# Altium schematic sheet CONNECTORS.SchDoc
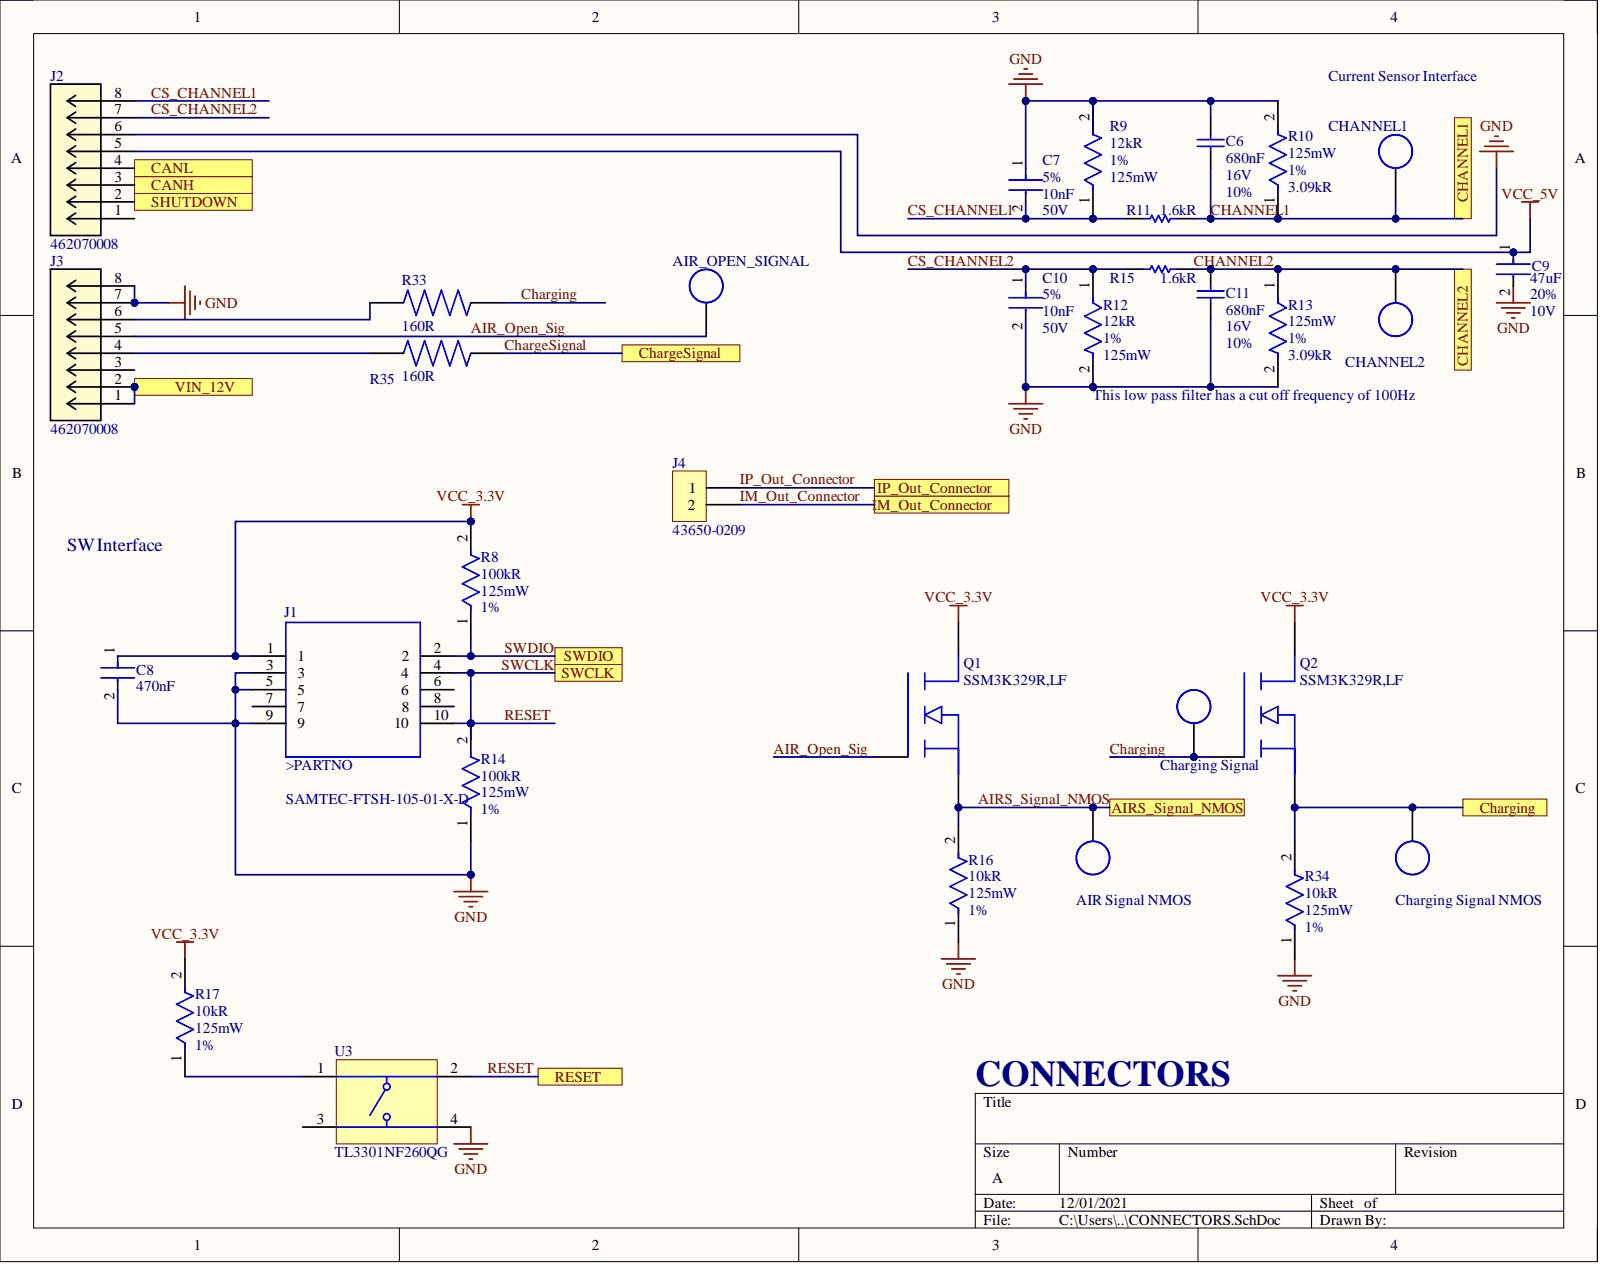
[diagram p0: CONNECTORS.SchDoc]

## Parts
AIR_Signal_NMOS  5015  [5015]  (value not drawn)
AIR_OPEN_SIGNAL  5015  [5015]  (value not drawn)
C6  Capacitance: 680nF  Tolerance: 10%  Voltage: 16V  [CAPC2013X95X45NL10T25]  (hidden comment: GRM219R71C684KA01D)
C7  Capacitance: 10nF  Tolerance: 5%  Voltage: 50V  [FP-GRM219-0_1-IPC_B]  (hidden comment: GRM2195C1H103JA01D)
C8  470nF  [FP-GCM21B-0_15-IPC_A]
C9  Capacitance: 47uF  Tolerance: 20%  Voltage: 10V  [FP-GRM21B-0_2-e0_2_0_7-IPC_A]  (hidden comment: GRM21BR61A476ME15L)
C10  Capacitance: 10nF  Tolerance: 5%  Voltage: 50V  [FP-GRM219-0_1-IPC_B]  (hidden comment: GRM2195C1H103JA01D)
C11  Capacitance: 680nF  Tolerance: 10%  Voltage: 16V  [CAPC2013X95X45NL10T25]  (hidden comment: GRM219R71C684KA01D)
CHANNEL1  5015  [5015]  (value not drawn)
CHANNEL2  5015  [5015]  (value not drawn)
Charging_Signal  5015  [5015]  (value not drawn)
Charging_Signal_NMOS  5015  [5015]  (value not drawn)
J1  SAMTEC-FTSH-105-01-X-D  [SAMTEC-FTSH-105-01-X-D]
J2  462070008  [CON_462070008]
J3  462070008  [CON_462070008]
J4  43650-0209  [43650-0209]
Q1  SSM3K329R,LF  [SOT23-3]
Q2  SSM3K329R,LF  [SOT23-3]
R8  Max Power Dissipation: 125mW  Resistance: 100kR  Resistance Tolerance: 1%  [FP-CRCW0805-e3-MFG]  (hidden comment: CRCW0805100KFKEA)
R9  Max Power Dissipation: 125mW  Resistance: 12kR  Resistance Tolerance: 1%  [FP-CRCW0805-e3-IPC_A]  (hidden comment: CRCW080512K0FKEA)
R10  Max Power Dissipation: 125mW  Resistance: 3.09kR  Resistance Tolerance: 1%  [FP-CRCW0805-e3-IPC_C]  (hidden comment: CRCW08053K09FKEA)
R11  Resistance: 1.6kR  [RESC2013X50X30LL20T20]  (hidden comment: CRCW08051K60FKEA)
R12  Max Power Dissipation: 125mW  Resistance: 12kR  Resistance Tolerance: 1%  [FP-CRCW0805-e3-IPC_A]  (hidden comment: CRCW080512K0FKEA)
R13  Max Power Dissipation: 125mW  Resistance: 3.09kR  Resistance Tolerance: 1%  [FP-CRCW0805-e3-IPC_C]  (hidden comment: CRCW08053K09FKEA)
R14  Max Power Dissipation: 125mW  Resistance: 100kR  Resistance Tolerance: 1%  [FP-CRCW0805-e3-MFG]  (hidden comment: CRCW0805100KFKEA)
R15  Resistance: 1.6kR  [RESC2013X50X30LL20T20]  (hidden comment: CRCW08051K60FKEA)
R16  Max Power Dissipation: 125mW  Resistance: 10kR  Resistance Tolerance: 1%  [FP-CRCW0805-e3-IPC_A]  (hidden comment: CRCW080510K0FKEA)
R17  Max Power Dissipation: 125mW  Resistance: 10kR  Resistance Tolerance: 1%  [FP-CRCW0805-e3-IPC_A]  (hidden comment: CRCW080510K0FKEA)
R33  Resistance: 160R  [RES_ERJP06J161V]  (hidden comment: ERJP06J161V)
R34  Max Power Dissipation: 125mW  Resistance: 10kR  Resistance Tolerance: 1%  [FP-CRCW0805-e3-IPC_A]  (hidden comment: CRCW080510K0FKEA)
R35  Resistance: 160R  [RES_ERJP06J161V]  (hidden comment: ERJP06J161V)
U3  TL3301NF260QG  [TECO-FSM4JSMA_V]

## Ports
AIRS_Signal_NMOS, CANH, CANL, CHANNEL1, CHANNEL2, ChargeSignal, Charging, IM_Out_Connector, IP_Out_Connector, RESET, SHUTDOWN, SWCLK, SWDIO, VIN_12V

## Nets
GND: C6.2 C7.1 C8.2 C9.2 C10.2 C11.1 J1.3 J1.5 J1.9 J2.6 J3.7 J3.8 R9.2 R10.2 R12.2 R13.2 R14.1 R16.1 R34.1 U3.4
VCC_3.3V: C8.1 J1.1 Q1.3 Q2.3 R8.2 R17.2
VCC_5V: C9.1 J2.5
AIR_Open_Sig: AIR_OPEN_SIGNAL.1 J3.5 Q1.1
AIRS_Signal_NMOS: AIR_Signal_NMOS.1 Q1.2 R16.2 ; port AIRS_Signal_NMOS
CANH: J2.3 ; port CANH
CANL: J2.4 ; port CANL
CHANNEL1: C6.1 CHANNEL1.1 R10.1 R11.2 ; port CHANNEL1
CHANNEL2: C11.2 CHANNEL2.1 R13.1 R15.2 ; port CHANNEL2
ChargeSignal: R35.1 ; port ChargeSignal
Charging: Charging_Signal.1 Q2.1 R33.1
CS_CHANNEL1: C7.2 J2.8 R9.1 R11.1
CS_CHANNEL2: C10.1 J2.7 R12.1 R15.1
IM_Out_Connector: J4.2 ; port IM_Out_Connector
IP_Out_Connector: J4.1 ; port IP_Out_Connector
RESET: J1.4 J1.10 R14.2 U3.2 ; port RESET, port SWCLK
SHUTDOWN: J2.2 ; port SHUTDOWN
SWDIO: J1.2 R8.1 ; port SWDIO
VIN_12V: J3.1 J3.2 ; port VIN_12V
NetCharging Signal NMOS_1: Charging_Signal_NMOS.1 Q2.2 R34.2 ; port Charging
NetJ3_4: J3.4 R35.2
NetJ3_6: J3.6 R33.2
NetR17_1: R17.1 U3.1

Not connected: J1 6 7 8; J2 1; J3 3; U3 3

## Library data of the parts
AIR_OPEN_SIGNAL AIR_Signal_NMOS CHANNEL1 CHANNEL2 Charging_Signal Charging_Signal_NMOS = 5015: SMD Test Point; Manufacturer: Keystone Electronics; TargetLibrary: RITRacing-Connectors; Component Kind: Standard; ComponentLink1Description: Datasheet; ComponentLink1URL: http://www.mouser.com/ds/2/215/005-5009-743680.pdf; Library Name: Connectors.IntLib; PartNumber: 5015; Published: 22Oct2016; Status: Active; Publisher: T.Tallo
C6 C11 = CMP-2007-00190-1: Manufacturer: Murata; Dielectric: X7R; Material: Ceramic; Case Code (Imperial): 0805; Case Code (Metric): 2012; Case/Package: 0805; Category: Ceramic Capacitors; Component Kind: Standard; ComponentLink1Description: https://datasheet.ciiva.com/136/grm219r71c684ka01-01-136854.pdf?src-supplier=Digi-Key; ComponentLink1URL: https://datasheet.ciiva.com/136/grm219r71c684ka01-01-136854.pdf?src-supplier=Digi-Key; ComponentLink2Description: https://datasheet.ciiva.com/136/grm219r71c684ka01-01-136855.pdf?src-supplier=Digi-Key; ComponentLink2URL: https://datasheet.ciiva.com/136/grm219r71c684ka01-01-136855.pdf?src-supplier=Digi-Key; ComponentLink3Description: https://datasheet.ciiva.com/136/grm219r71c684ka01-01-136856.pdf?src-supplier=Digi-Key; ComponentLink3URL: https://datasheet.ciiva.com/136/grm219r71c684ka01-01-136856.pdf?src-supplier=Digi-Key; ComponentLink4Description: https://datasheet.ciiva.com/5426/product-5426512.pdf?src-supplier=Mouser; ComponentLink4URL: https://datasheet.ciiva.com/5426/product-5426512.pdf?src-supplier=Mouser; ComponentLink5Description: https://datasheet.ciiva.com/11464/product-11464042.pdf?src-supplier=Avnet; ComponentLink5URL: https://datasheet.ciiva.com/11464/product-11464042.pdf?src-supplier=Avnet; Construction: Flat; Depth: 1.25mm; Height: 0.85mm; Lead Free: Lead Free; Length: 2mm; Library Name: Altium Content Vault; Manufacturer 1: Murata; Manufacturer 2: Murata; Manufacturer 3: Murata; Manufacturer Part Number 1: GRM219R71C684KA01D; Manufacturer Part Number 2: GRM219R71C684KA01D; Manufacturer Part Number 3: GRM219R71C684KA01D; Max Operating Temperature: 125°C; Min Operating Temperature: -55°C; Mount: Surface Mount; Package Quantity: 1; Packaging: Tape and Reel; Part Number: GRM219R71C684KA01D; Pricing 1: 1=0.33000, 10=0.22300, 50=0.14860, 100=0.12630, 500=0.08914, 1000=0.07800 (USD); Pricing 2: 1=0.33000, 10=0.22300, 50=0.14860, 100=0.12630, 500=0.08914, 1000=0.07800 (USD); Pricing 3: 1=0.32000, 10=0.22300, 50=0.22300, 100=0.12700, 500=0.09000, 1000=0.07800, 2000=0.07500, 4000=0.06800, 8000=0.05600, 10000=0.05600 (USD); Radiation Hardening: No; RoHS: Yes; RoHS Compliant: Yes; Series: GRM; Stock 1: 28535; Stock 2: 28535; Stock 3: 83; Supplier 1: Digi-Key; Supplier 2: Digi-Key; Supplier 3: Mouser; Supplier Part Number 1: 490-4741-1-ND; Supplier Part Number 2: 490-4741-6-ND; Supplier Part Number 3: 81-GRM219R71C684KA1D; Termination: SMD/SMT; Thickness: 0.037inch; Voltage Rating: 16V; Voltage Rating (DC): 16V; Width: 1.25mm
C7 C10 = CMP-2007-00135-2: Chip Multilayer Ceramic Capacitors for General Purpose, 0805, 10000pF, C0G, 30ppm/°C, 5%, 50V; Manufacturer 1: Murata; Insulation Resistance: 10GR; Case Code (Imperial): 0805; Case Code (Metric): 2012; Case/Package: 0805; Category: Ceramic Capacitors; Component Kind: Standard; ComponentLink1Description: https://datasheet.ciiva.com/3777/376grm2195c1h103ja0123-3777227.pdf?src-supplier=Arrow+Electronics; ComponentLink1URL: https://datasheet.ciiva.com/3777/376grm2195c1h103ja0123-3777227.pdf?src-supplier=Arrow+Electronics; ComponentLink2Description: https://datasheet.ciiva.com/11786/0900766b807a1cab-11786595.pdf?src-supplier=RSComponents; ComponentLink2URL: https://datasheet.ciiva.com/11786/0900766b807a1cab-11786595.pdf?src-supplier=RSComponents; ComponentLink3Description: https://datasheet.ciiva.com/19476/1858501-19476200.pdf?src-supplier=Jameco; ComponentLink3URL: https://datasheet.ciiva.com/19476/1858501-19476200.pdf?src-supplier=Jameco; ComponentLink4Description: https://datasheet.ciiva.com/29200/452695-da-01-en-vs-kondensator-0805-29200853.pdf?src-supplier=Conrad; ComponentLink4URL: https://datasheet.ciiva.com/29200/452695-da-01-en-vs-kondensator-0805-29200853.pdf?src-supplier=Conrad; ComponentLink5Description: https://datasheet.ciiva.com/138/partnumbering-e-01-138167.pdf?src-supplier=Digi-Key; ComponentLink5URL: https://datasheet.ciiva.com/138/partnumbering-e-01-138167.pdf?src-supplier=Digi-Key; ComponentLink6Description: https://datasheet.ciiva.com/138/partnumbering-e-01-138165.pdf?src-supplier=Digi-Key; ComponentLink6URL: https://datasheet.ciiva.com/138/partnumbering-e-01-138165.pdf?src-supplier=Digi-Key; ComponentLink7Description: https://datasheet.ciiva.com/138/partnumbering-e-01-138166.pdf?src-supplier=Digi-Key; ComponentLink7URL: https://datasheet.ciiva.com/138/partnumbering-e-01-138166.pdf?src-supplier=Digi-Key; ComponentLink8Description: https://datasheet.ciiva.com/2989/product-2989652.pdf?src-supplier=Avnet; ComponentLink8URL: https://datasheet.ciiva.com/2989/product-2989652.pdf?src-supplier=Avnet; ComponentLink9Description: https://datasheet.ciiva.com/11620/product-11620062.pdf?src-supplier=Avnet; ComponentLink9URL: https://datasheet.ciiva.com/11620/product-11620062.pdf?src-supplier=Avnet; ComponentLink10Description: https://datasheet.ciiva.com/7828/4360-7828972.pdf?src-supplier=Element14; ComponentLink10URL: https://datasheet.ciiva.com/7828/4360-7828972.pdf?src-supplier=Element14; ComponentLink11Description: https://datasheet.ciiva.com/7828/1747462-7828970.pdf?src-supplier=Element14; ComponentLink11URL: https://datasheet.ciiva.com/7828/1747462-7828970.pdf?src-supplier=Element14; ComponentLink12Description: https://datasheet.ciiva.com/7828/1747462-7828971.pdf?src-supplier=Element14; ComponentLink12URL: https://datasheet.ciiva.com/7828/1747462-7828971.pdf?src-supplier=Element14; Construction: Flat; Depth: 1.25mm; Dielectric: C0G; Dielectric Material: Ceramic; Height: 0.85mm; Lead Free: Lead Free; Length: 2mm; Library Name: Altium Content Vault; Manufacturer 2: Murata; Manufacturer Part Number 1: GRM2195C1H103JA01D; Manufacturer Part Number 2: GRM2195C1H103JA01D; Material: Ceramic; Max Operating Temperature: 125°C; Min Operating Temperature: -55°C; Mount: Surface Mount; Number of Pins: 2; Package Quantity: 1; Packaging: Tape and Reel; Pricing 1: 1=0.09100, 4000=0.09100 (USD); Pricing 2: 1=0.38000, 10=0.25900, 50=0.17300, 100=0.14700, 500=0.10378, 1000=0.09080 (USD); Radiation Hardening: No; REACH SVHC: No SVHC; RoHS: Yes; RoHS Compliant: Yes; Series: GRM; Size: 0.85mm; Stock 1: 44000; Supplier 1: Newark; Supplier 2: Digi-Key; Supplier Part Number 1: 08AC2712; Supplier Part Number 2: 490-1642-1-ND; Temperature Coefficient: 30ppm/°C; Termination: SMD/SMT; Thickness: 0.037inch; Voltage Rating: 50V; Voltage Rating (DC): 50V; Width: 0.049inch
C8 = CMP-2007-03360-2: Ceramic Capacitor for Automotive 470nF ±10% 50VDC X7R 0805 Embossed T/R; Manufacturer 1: Murata; Manufacturer Part Number 1: GCM21BR71H474KA55K; Voltage Rating: 50V; Capacitance: 470nF; Case Code (Imperial): 0805; Case Code (Metric): 2012; Case/Package: 0805; Category: Ceramic Capacitors; Component Kind: Standard; ComponentLink1Description: https://datasheet.ciiva.com/171/product-171550.pdf?src-supplier=Digi-Key; ComponentLink1URL: https://datasheet.ciiva.com/171/product-171550.pdf?src-supplier=Digi-Key; ComponentLink2Description: https://datasheet.ciiva.com/11673/product-11673422.pdf?src-supplier=Avnet; ComponentLink2URL: https://datasheet.ciiva.com/11673/product-11673422.pdf?src-supplier=Avnet; Construction: Flat; Depth: 1.25mm; Dielectric: X7R; Height: 1.25mm; Length: 2mm; Library Name: Altium Content Vault; Max Operating Temperature: 125°C; Min Operating Temperature: -55°C; Mount: Surface Mount; Package Quantity: 10000; Packaging: Tape and Reel; Pricing 1: 1=0.30000, 10=0.20800, 50=0.13860, 100=0.11780, 500=0.08318, 1000=0.07279, 2500=0.06932, 5000=0.06412 (USD); Radiation Hardening: No; Ratings: AEC-Q200; RoHS: Yes; RoHS Compliant: Yes; Series: GCM; Stock 1: 26700; Supplier 1: Digi-Key; Supplier Part Number 1: 490-11164-1-ND; Termination: SMD/SMT; Thickness: 0.055inch; Tolerance: 10%; Voltage Rating (DC): 50V; Width: 0.049inch
C9 = CMP-2000-06341-2: Chip Multilayer Ceramic Capacitors for General Purpose, 0805, 47uF, X5R, 15%, 20%, 10V; Manufacturer 1: Murata; Dissipation Factor: 0.035%; Case Code (Imperial): 0805; Case Code (Metric): 2012; Case/Package: 0805; Category: Ceramic Capacitors; Component Kind: Standard; ComponentLink1Description: http://www.farnell.com/datasheets/2079183.pdf?src-supplier=Farnell; ComponentLink1URL: http://www.farnell.com/datasheets/2079183.pdf?src-supplier=Farnell; ComponentLink2Description: http://components.arrow.com/part/dataview/0323_07199600?src-supplier=Arrow+Europe; ComponentLink2URL: http://components.arrow.com/part/dataview/0323_07199600?src-supplier=Arrow+Europe; ComponentLink3Description: http://psearch.en.murata.com/capacitor/product/GRM21BR61A476ME15%23.pdf?src-supplier=Avnet; ComponentLink3URL: http://psearch.en.murata.com/capacitor/product/GRM21BR61A476ME15%23.pdf?src-supplier=Avnet; ComponentLink4Description: https://search.murata.co.jp/Ceramy/image/img/A01X/G101/ENG/GRM21BR61A476ME15-01.pdf?src-supplier=Digi-Key; ComponentLink4URL: https://search.murata.co.jp/Ceramy/image/img/A01X/G101/ENG/GRM21BR61A476ME15-01.pdf?src-supplier=Digi-Key; ComponentLink5Description: http://search.murata.co.jp/Ceramy/image/img/A01X/G101/ENG/GRM21BR61A476ME15-01.pdf?src-supplier=Digi-Key; ComponentLink5URL: http://search.murata.co.jp/Ceramy/image/img/A01X/G101/ENG/GRM21BR61A476ME15-01.pdf?src-supplier=Digi-Key; ComponentLink6Description: http://www.farnell.com/datasheets/2079183.pdf?src-supplier=Element14; ComponentLink6URL: http://www.farnell.com/datasheets/2079183.pdf?src-supplier=Element14; ComponentLink7Description: http://www.farnell.com/datasheets/2079183.pdf?src-supplier=Newark; ComponentLink7URL: http://www.farnell.com/datasheets/2079183.pdf?src-supplier=Newark; Depth: 1.25mm; Dielectric: X5R; Dielectric Material: Ceramic; Height: 1.25mm; Lead Free: Lead Free; Length: 2mm; Library Name: Altium Content Vault; Manufacturer Part Number 1: GRM21BR61A476ME15L; Max Operating Temperature: 85°C; Min Operating Temperature: -55°C; Mount: Surface Mount; Packaging: Tape and Reel; Pricing 1: 3000=0.19360 (USD); REACH SVHC: No SVHC; RoHS: Yes; RoHS Compliant: Yes; Stock 1: 291985; Supplier 1: Digi-Key; Supplier Part Number 1: 490-9961-2-ND; Termination: SMD/SMT; Thickness: 0.057inch; Voltage Rating: 10V; Voltage Rating (DC): 10V; Width: 0.049inch
J1 = SAMTEC-FTSH-105-01-X-D: CONN HEADER SMD 10POS 1.27MM; Manufacturer 1: Samtec; Manufacturer Part Number 1: FTSH-105-01-L-DV-K; Connector Type: Male Pin; Gender: Male; Max Current Rating: 1.75A; MP: FTSH-105-01-F-D; Number of Contacts: 10; Row Spacing: 0.05inch; Availability: Unavailable; Category: Headers and Wire Housings; Color: Black; Component Kind: Standard; ComponentLink1Description: https://datasheet.ciiva.com/26936/getdatasheetpartid-175877-26936879.pdf?src-supplier=Verical; ComponentLink1URL: https://datasheet.ciiva.com/26936/getdatasheetpartid-175877-26936879.pdf?src-supplier=Verical; ComponentLink2Description: https://datasheet.ciiva.com/22703/ftsh-smt-22703900.pdf?src-supplier=Element14; ComponentLink2URL: https://datasheet.ciiva.com/22703/ftsh-smt-22703900.pdf?src-supplier=Element14; ComponentLink3Description: https://datasheet.ciiva.com/10245/ftsh-mt-10245272.pdf?src-supplier=Avnet; ComponentLink3URL: https://datasheet.ciiva.com/10245/ftsh-mt-10245272.pdf?src-supplier=Avnet; ComponentLink4Description: https://datasheet.ciiva.com/16657/ftsh-mt-16657372.pdf?src-supplier=Avnet+Europe; ComponentLink4URL: https://datasheet.ciiva.com/16657/ftsh-mt-16657372.pdf?src-supplier=Avnet+Europe; ComponentLink5Description: https://datasheet.ciiva.com/728/ftsh-1xx-xx-xxx-dv-xxx-mkt-728872.pdf?src-supplier=Digi-Key; ComponentLink5URL: https://datasheet.ciiva.com/728/ftsh-1xx-xx-xxx-dv-xxx-mkt-728872.pdf?src-supplier=Digi-Key; Contact Material: Bronze; Contact Mating Length: 0.12inch; Contact Plating: Gold; Contact Type: Male Pin; Depth: 3.43mm; Housing Color: Black; Housing Material: Polymer; Lead Free: Lead Free; Length: 6.35mm; Library Name: SnapEDAsch.SchLib; Max Operating Temperature: 125°C; MF: Samtec; Min Operating Temperature: -55°C; Mount: Surface Mount; Number of Positions: 10; Number of Rows: 2; Orientation: Straight; Package: None; Package Quantity: 1; Packaging: Rail/Tube; Pitch: 1.27mm; Price: None; Pricing 1: 1=3.91000, 10=3.75700, 92=3.13098, 460=2.66135, 1012=2.26997 (USD); Radiation Hardening: No; REACH SVHC: No SVHC; RoHS: Yes; RoHS Compliant: Yes; Series: FTSH; SnapEDA_Link: https://www.snapeda.com/parts/FTSH-105-01-F-D/Samtec%20Inc./view-part/105348/?ref=snap; Stock 1: 38057; Supplier 1: Digi-Key; Supplier Part Number 1: SAM8799-ND; Termination: Solder
J2 J3 = 462070008: Conn Power HDR 8 POS 4.2mm Solder ST Thru-Hole 8 Terminal 1 Port Mini-Fit Jr™ Frame; Manufacturer 1: Molex; Manufacturer Part Number 1: 46207-0008; Mfr_Name: Molex Connector Corporation; Connector Type: Male Pin; Current Rating: 13A; Glow Wire Compliant: Compliant; Max Voltage Rating (DC): 600.6kV; Number of Circuits: 8; Row Spacing: 0.165inch; Voltage Rating: 600V; Category: Headers and Wire Housings; Color: Black; Component Kind: Standard; ComponentLink1Description: https://datasheet.ciiva.com/726/462070004-sd-726556.pdf?src-supplier=Digi-Key; ComponentLink1URL: https://datasheet.ciiva.com/726/462070004-sd-726556.pdf?src-supplier=Digi-Key; ComponentLink2Description: https://datasheet.ciiva.com/5159/0462070008-pcb-headers-169853-5159064.pdf?src-supplier=Mouser; ComponentLink2URL: https://datasheet.ciiva.com/5159/0462070008-pcb-headers-169853-5159064.pdf?src-supplier=Mouser; ComponentLink3Description: https://datasheet.ciiva.com/11751/0900766b80b0ac72-11751180.pdf?src-supplier=RSComponents; ComponentLink3URL: https://datasheet.ciiva.com/11751/0900766b80b0ac72-11751180.pdf?src-supplier=RSComponents; ComponentLink4Description: https://datasheet.ciiva.com/30199/145480462070008-pcb-headers-30199794.pdf?src-supplier=Onlinecomponents.com; ComponentLink4URL: https://datasheet.ciiva.com/30199/145480462070008-pcb-headers-30199794.pdf?src-supplier=Onlinecomponents.com; ComponentLink5Description: https://datasheet.ciiva.com/25289/1630792-25289386.pdf?src-supplier=Newark; ComponentLink5URL: https://datasheet.ciiva.com/25289/1630792-25289386.pdf?src-supplier=Newark; ComponentLink6Description: https://datasheet.ciiva.com/16653/0462070008-pcb-headers-16653783.pdf?src-supplier=Avnet+Europe; ComponentLink6URL: https://datasheet.ciiva.com/16653/0462070008-pcb-headers-16653783.pdf?src-supplier=Avnet+Europe; Contact Gender: Male; Contact Material: Brass; Contact Plating: Tin; Contact Type: Male Pin; Fastening Type: Ramp; Halogen Free: Low Halogen; Housing Material: Polymer; Library Name: SnapEDAsch.SchLib; Manufacturer_Part_Number: 462070008; Max Operating Temperature: 105°C; Min Operating Temperature: -40°C; Mount: Through Hole; Number of Contacts: 8; Number of Positions: 8; Number of Rows: 2; Orientation: Vertical; Package Quantity: 1; Packaging: Tray; Pitch: 4.2mm; Pricing 1: 1=2.76000, 10=2.34700, 80=1.93888, 3120=1.42868, 6240=1.35724 (USD); Radiation Hardening: No; RefDes: RefDes; RoHS: Yes; RoHS Compliant: Yes; Series: Mini-Fit® 46207; Stock 1: 3111; Supplier 1: Digi-Key; Supplier Part Number 1: WM21377-ND; Termination: Solder; Type: DEV; Voltage Rating (AC): 600V; Copyright: Copyright (C) 2021 Ultra Librarian. All rights reserved.
J4 = 43650-0209: Molex 2 Position Board Header, RIght Angle; TargetLibrary: RITRacing-Connectors; Voltage: 600V; Component Kind: Standard; ComponentLink1Description: Datasheet; ComponentLink1URL: http://www.mouser.com/ds/2/418/NG_CD_1445088_A1-614413.pdf; Library Name: Connectors.IntLib; Manufacturer: Molex; PartNumber: 43650-0209; Published: 13Aug2018; Status: Active; Supplier 1: Mouser; Supplier 2: Digi-Key; Supplier Part Number 1: 538-43650-0209; Publisher: T.Tallo
Q1 Q2 = SSM3K329R,LF: N-Channel MOSFET, 30V, 3.5A; Manufacturer: Toshiba; TargetLibrary: RITRacing-Transistors; Component Kind: Standard; ComponentLink1Description: Datasheet; ComponentLink1URL: See Digikey; Library Name: Transistors.IntLib; PartNumber: SSM3K329R,LF; Published: 09/27/2017; Status: Active; Supplier 1: Digi-Key; Supplier Part Number 1: SSM3K329RLFDKR-ND; Publisher: T.Tallo
R8 R14 = CMP-2001-04899-2: RES Thick Film, 100kΩ, 1%, 0.125W, 100ppm/°C, 0805; Manufacturer 1: Vishay; Voltage Rating: 150V; Case Code (Imperial): 0805; Case Code (Metric): 2012; Case/Package: 0805; Category: Chip SMD Resistors; Component Kind: Standard; ComponentLink1Description: https://datasheet.ciiva.com/11809/0900766b80d98b26-11809057.pdf?src-supplier=RSComponents; ComponentLink1URL: https://datasheet.ciiva.com/11809/0900766b80d98b26-11809057.pdf?src-supplier=RSComponents; ComponentLink2Description: https://datasheet.ciiva.com/8337/dcrcwe3-8337738.pdf?src-supplier=Avnet; ComponentLink2URL: https://datasheet.ciiva.com/8337/dcrcwe3-8337738.pdf?src-supplier=Avnet; ComponentLink3Description: https://datasheet.ciiva.com/3258/dcrcwe3-3258006.pdf?src-supplier=Avnet; ComponentLink3URL: https://datasheet.ciiva.com/3258/dcrcwe3-3258006.pdf?src-supplier=Avnet; ComponentLink4Description: https://datasheet.ciiva.com/16754/70201119-16754822.pdf?src-supplier=Allied+Electronics; ComponentLink4URL: https://datasheet.ciiva.com/16754/70201119-16754822.pdf?src-supplier=Allied+Electronics; ComponentLink5Description: https://datasheet.ciiva.com/7777/1697337-7777349.pdf?src-supplier=Element14; ComponentLink5URL: https://datasheet.ciiva.com/7777/1697337-7777349.pdf?src-supplier=Element14; ComponentLink6Description: https://datasheet.ciiva.com/7777/1720486-7777565.pdf?src-supplier=Element14; ComponentLink6URL: https://datasheet.ciiva.com/7777/1720486-7777565.pdf?src-supplier=Element14; ComponentLink7Description: https://datasheet.ciiva.com/16341/dcrcwe3-16341530.pdf?src-supplier=Future+Electronics; ComponentLink7URL: https://datasheet.ciiva.com/16341/dcrcwe3-16341530.pdf?src-supplier=Future+Electronics; ComponentLink8Description: https://datasheet.ciiva.com/16852/dcrcwe3-16852810.pdf?src-supplier=Avnet+Europe; ComponentLink8URL: https://datasheet.ciiva.com/16852/dcrcwe3-16852810.pdf?src-supplier=Avnet+Europe; ComponentLink9Description: https://datasheet.ciiva.com/16720/70201119-16720891.pdf?src-supplier=Allied+Electronics; ComponentLink9URL: https://datasheet.ciiva.com/16720/70201119-16720891.pdf?src-supplier=Allied+Electronics; Composition: Thick Film; Construction: Rectangular; Contact Plating: Tin; Depth: 1.25mm; Features: Automotive AEC-Q200; Height: 0.45mm; Lead Free: Lead Free; Length: 2mm; Library Name: Altium Content Vault; Manufacturer Part Number 1: CRCW0805100KFKEA; Max Operating Temperature: 155°C; Military Standard: Not; Min Operating Temperature: -55°C; Mount: Surface Mount; Number of Pins: 2; Number of Terminations: 2; Package Quantity: 5000; Packaging: Tape and Reel; Power Rating: 125mW; Pricing 1: 1=0.10000, 10=0.06700, 100=0.02710, 1000=0.01219, 2500=0.01058 (USD); Radiation Hardening: No; REACH SVHC: Unknown; Resistor Type: Thick Film; RoHS: Yes; RoHS Compliant: Yes; Series: CRCW; Size Code: 0805; Stock 1: 135309; Supplier 1: Digi-Key; Supplier Part Number 1: 541-100KCCT-ND; Technology: THICK FILM; Temperature Coefficient: 100ppm/°C; Termination: SMD/SMT; Tolerance: 1%; Voltage Rating (DC): 150V; Weight: 0.000194oz; Width: 1.25mm
R9 R12 = CMP-2001-04934-2: RES Thick Film, 12kΩ, 1%, 0.125W, 100ppm/°C, 0805; Manufacturer 1: Vishay; Voltage Rating: 150V; Case Code (Imperial): 0805; Case Code (Metric): 2012; Case/Package: 0805; Category: Chip SMD Resistors; Component Kind: Standard; ComponentLink1Description: https://datasheet.ciiva.com/11809/0900766b80d98b26-11809216.pdf?src-supplier=RSComponents; ComponentLink1URL: https://datasheet.ciiva.com/11809/0900766b80d98b26-11809216.pdf?src-supplier=RSComponents; ComponentLink2Description: https://datasheet.ciiva.com/2467/dcrcwe3-2467344.pdf?src-supplier=Digi-Key; ComponentLink2URL: https://datasheet.ciiva.com/2467/dcrcwe3-2467344.pdf?src-supplier=Digi-Key; ComponentLink3Description: https://datasheet.ciiva.com/2467/dcrcwe3-2467345.pdf?src-supplier=Digi-Key; ComponentLink3URL: https://datasheet.ciiva.com/2467/dcrcwe3-2467345.pdf?src-supplier=Digi-Key; ComponentLink4Description: https://datasheet.ciiva.com/2467/dcrcwe3-2467346.pdf?src-supplier=Digi-Key; ComponentLink4URL: https://datasheet.ciiva.com/2467/dcrcwe3-2467346.pdf?src-supplier=Digi-Key; ComponentLink5Description: https://datasheet.ciiva.com/16883/dcrcwe3-16883879.pdf?src-supplier=Avnet+Europe; ComponentLink5URL: https://datasheet.ciiva.com/16883/dcrcwe3-16883879.pdf?src-supplier=Avnet+Europe; ComponentLink6Description: https://datasheet.ciiva.com/16397/dcrcw-16397325.pdf?src-supplier=Future+Electronics; ComponentLink6URL: https://datasheet.ciiva.com/16397/dcrcw-16397325.pdf?src-supplier=Future+Electronics; ComponentLink7Description: https://datasheet.ciiva.com/7841/1697337-7841630.pdf?src-supplier=Element14; ComponentLink7URL: https://datasheet.ciiva.com/7841/1697337-7841630.pdf?src-supplier=Element14; ComponentLink8Description: https://datasheet.ciiva.com/16777/70201119-16777994.pdf?src-supplier=Allied+Electronics; ComponentLink8URL: https://datasheet.ciiva.com/16777/70201119-16777994.pdf?src-supplier=Allied+Electronics; Composition: Thick Film; Construction: Rectangular; Contact Plating: Tin; Depth: 1.25mm; Features: Automotive AEC-Q200; Height: 0.45mm; Lead Free: Lead Free; Length: 2mm; Library Name: Altium Content Vault; Manufacturer Part Number 1: CRCW080512K0FKEA; Max Operating Temperature: 155°C; Military Standard: Not; Min Operating Temperature: -55°C; Mount: Surface Mount; Number of Terminations: 2; Package Quantity: 10; Packaging: Tape and Reel; Power Rating: 125mW; Pricing 1: 1=0.10000, 10=0.06700, 100=0.02710, 1000=0.01219, 2500=0.01058 (USD); Radiation Hardening: No; REACH SVHC: Unknown; Resistor Type: Thick Film; RoHS: Yes; RoHS Compliant: Yes; Series: CRCW; Size Code: 0805; Stock 1: 2287; Supplier 1: Digi-Key; Supplier Part Number 1: 541-12.0KCCT-ND; Technology: THICK FILM; Temperature Coefficient: 100ppm/°C; Termination: SMD/SMT; Tolerance: 1%; Voltage Rating (DC): 150V; Weight: 0.000194oz; Width: 0.049inch
R10 R13 = CMP-2001-03873-2: RES Thick Film, 3.09kΩ, 1%, 0.125W, 100ppm/°C, 0805; Manufacturer 1: Vishay; Voltage Rating: 150V; Case Code (Imperial): 0805; Case Code (Metric): 2012; Case/Package: 0805; Category: Chip SMD Resistors; Component Kind: Standard; ComponentLink1Description: https://datasheet.ciiva.com/9081/dcrcwe3-9081688.pdf?src-supplier=Avnet; ComponentLink1URL: https://datasheet.ciiva.com/9081/dcrcwe3-9081688.pdf?src-supplier=Avnet; ComponentLink2Description: https://datasheet.ciiva.com/2467/dcrcwe3-2467557.pdf?src-supplier=Digi-Key; ComponentLink2URL: https://datasheet.ciiva.com/2467/dcrcwe3-2467557.pdf?src-supplier=Digi-Key; ComponentLink3Description: https://datasheet.ciiva.com/2467/dcrcwe3-2467558.pdf?src-supplier=Digi-Key; ComponentLink3URL: https://datasheet.ciiva.com/2467/dcrcwe3-2467558.pdf?src-supplier=Digi-Key; ComponentLink4Description: https://datasheet.ciiva.com/2467/dcrcwe3-2467559.pdf?src-supplier=Digi-Key; ComponentLink4URL: https://datasheet.ciiva.com/2467/dcrcwe3-2467559.pdf?src-supplier=Digi-Key; ComponentLink5Description: https://datasheet.ciiva.com/5549/dcrcwe3-109170-5549629.pdf?src-supplier=Mouser; ComponentLink5URL: https://datasheet.ciiva.com/5549/dcrcwe3-109170-5549629.pdf?src-supplier=Mouser; ComponentLink6Description: https://datasheet.ciiva.com/7670/1697337-7670298.pdf?src-supplier=Element14; ComponentLink6URL: https://datasheet.ciiva.com/7670/1697337-7670298.pdf?src-supplier=Element14; ComponentLink7Description: https://datasheet.ciiva.com/7670/1697337-7670299.pdf?src-supplier=Element14; ComponentLink7URL: https://datasheet.ciiva.com/7670/1697337-7670299.pdf?src-supplier=Element14; ComponentLink8Description: https://datasheet.ciiva.com/22675/1847332-22675199.pdf?src-supplier=Element14; ComponentLink8URL: https://datasheet.ciiva.com/22675/1847332-22675199.pdf?src-supplier=Element14; ComponentLink9Description: https://datasheet.ciiva.com/7178/1635184-7178791.pdf?src-supplier=Newark; ComponentLink9URL: https://datasheet.ciiva.com/7178/1635184-7178791.pdf?src-supplier=Newark; ComponentLink10Description: https://datasheet.ciiva.com/22871/70201119-22871769.pdf?src-supplier=Allied+Electronics; ComponentLink10URL: https://datasheet.ciiva.com/22871/70201119-22871769.pdf?src-supplier=Allied+Electronics; ComponentLink11Description: https://datasheet.ciiva.com/16780/70201119-16780488.pdf?src-supplier=Allied+Electronics; ComponentLink11URL: https://datasheet.ciiva.com/16780/70201119-16780488.pdf?src-supplier=Allied+Electronics; Composition: Thick Film; Construction: Rectangular; Contact Plating: Tin; Depth: 1.25mm; Features: Automotive AEC-Q200; Height: 0.02inch; Lead Free: Lead Free; Length: 2mm; Library Name: Altium Content Vault; Manufacturer 2: Vishay; Manufacturer 3: Vishay; Manufacturer Part Number 1: CRCW08053K09FKEA; Manufacturer Part Number 2: CRCW08053K09FKEA; Manufacturer Part Number 3: CRCW08053K09FKEA; Max Operating Temperature: 155°C; Military Standard: Not; Min Operating Temperature: -55°C; Number of Pins: 2; Number of Terminations: 2; Package Quantity: 10; Packaging: Tape and Reel; Power Rating: 125mW; Pricing 1: 1=0.10000, 10=0.06700, 100=0.02710, 1000=0.01219, 2500=0.01058 (USD); Pricing 2: 1=0.10000, 10=0.06700, 100=0.02710, 1000=0.01219, 2500=0.01058 (USD); Pricing 3: 1=0.18000, 10=0.06800, 50=0.06800, 100=0.02800, 1000=0.01500, 10000=0.00900 (USD); Radiation Hardening: No; REACH SVHC: Unknown; Resistor Type: Thick Film; RoHS: Yes; RoHS Compliant: Yes; Series: CRCW; Size Code: 0805; Stock 1: 4900; Stock 2: 4900; Stock 3: 6174; Supplier 1: Digi-Key; Supplier 2: Digi-Key; Supplier 3: Mouser; Supplier Part Number 1: 541-3.09KCCT-ND; Supplier Part Number 2: 541-3.09KCDKR-ND; Supplier Part Number 3: 71-CRCW0805-3.09K-E3; Technology: THICK FILM; Temperature Coefficient: 100ppm/°C; Termination: Solder; Tolerance: 1%; Voltage Rating (DC): 150V; Weight: 0.000194oz; Width: 0.049inch
R11 R15 = CMP-1013-00531-2: Manufacturer: Vishay Dale; Manufacturer 1: Vishay; Max Power Dissipation: 125mW; Resistance Tolerance: 1%; Temperature Coefficient: 100ppm/°C; Voltage Rating: 150V; Case Code (Imperial): 0805; Case Code (Metric): 2012; Case/Package: 0805; Category: Chip SMD Resistors; Component Kind: Standard; ComponentLink1Description: https://datasheet.ciiva.com/11808/0900766b80d98b26-11808292.pdf?src-supplier=RSComponents; ComponentLink1URL: https://datasheet.ciiva.com/11808/0900766b80d98b26-11808292.pdf?src-supplier=RSComponents; ComponentLink2Description: https://datasheet.ciiva.com/5542/dcrcwe3-109170-5542582.pdf?src-supplier=Mouser; ComponentLink2URL: https://datasheet.ciiva.com/5542/dcrcwe3-109170-5542582.pdf?src-supplier=Mouser; ComponentLink3Description: https://datasheet.ciiva.com/2495/dcrcwe3-2495824.pdf?src-supplier=Digi-Key; ComponentLink3URL: https://datasheet.ciiva.com/2495/dcrcwe3-2495824.pdf?src-supplier=Digi-Key; ComponentLink4Description: https://datasheet.ciiva.com/2495/dcrcwe3-2495825.pdf?src-supplier=Digi-Key; ComponentLink4URL: https://datasheet.ciiva.com/2495/dcrcwe3-2495825.pdf?src-supplier=Digi-Key; ComponentLink5Description: https://datasheet.ciiva.com/2495/dcrcwe3-2495826.pdf?src-supplier=Digi-Key; ComponentLink5URL: https://datasheet.ciiva.com/2495/dcrcwe3-2495826.pdf?src-supplier=Digi-Key; ComponentLink6Description: https://datasheet.ciiva.com/26312/fld-crcw-e3-data-en-26312927.pdf?src-supplier=Distrelec; ComponentLink6URL: https://datasheet.ciiva.com/26312/fld-crcw-e3-data-en-26312927.pdf?src-supplier=Distrelec; ComponentLink7Description: https://datasheet.ciiva.com/7670/1697337-7670266.pdf?src-supplier=Element14; ComponentLink7URL: https://datasheet.ciiva.com/7670/1697337-7670266.pdf?src-supplier=Element14; ComponentLink8Description: https://datasheet.ciiva.com/7670/1697337-7670267.pdf?src-supplier=Element14; ComponentLink8URL: https://datasheet.ciiva.com/7670/1697337-7670267.pdf?src-supplier=Element14; ComponentLink9Description: https://datasheet.ciiva.com/22700/1697337-22700735.pdf?src-supplier=Element14; ComponentLink9URL: https://datasheet.ciiva.com/22700/1697337-22700735.pdf?src-supplier=Element14; ComponentLink10Description: https://datasheet.ciiva.com/16852/dcrcwe3-16852921.pdf?src-supplier=Avnet+Europe; ComponentLink10URL: https://datasheet.ciiva.com/16852/dcrcwe3-16852921.pdf?src-supplier=Avnet+Europe; ComponentLink11Description: https://datasheet.ciiva.com/7725/66915-7725838.pdf?src-supplier=Element14; ComponentLink11URL: https://datasheet.ciiva.com/7725/66915-7725838.pdf?src-supplier=Element14; ComponentLink12Description: https://datasheet.ciiva.com/22683/1847332-22683309.pdf?src-supplier=Element14; ComponentLink12URL: https://datasheet.ciiva.com/22683/1847332-22683309.pdf?src-supplier=Element14; Construction: Rectangular; Contact Plating: Tin; Depth: 1.25mm; Features: Automotive AEC-Q200; Height: 0.45mm; Lead Free: Lead Free; Length: 2mm; Library Name: Altium Content Vault; Manufacturer 2: Vishay; Manufacturer 3: Vishay; Manufacturer Part Number 1: CRCW08051K60FKEA; Manufacturer Part Number 2: CRCW08051K60FKEA; Manufacturer Part Number 3: CRCW08051K60FKEA; Max Operating Temperature: 155°C; Military Standard: Not; Min Operating Temperature: -55°C; Number of Pins: 2; Number of Terminations: 2; Package Quantity: 5000; Packaging: Tape and Reel; Part Number: CRCW08051K60FKEA; Power Rating: 125mW; Pricing 1: 1=0.10000, 10=0.06700, 100=0.02710, 1000=0.01219, 2500=0.01058 (USD); Pricing 2: 1=0.10000, 10=0.06700, 100=0.02710, 1000=0.01219, 2500=0.01058 (USD); Pricing 3: 5000=0.00874 (USD); Radiation Hardening: No; REACH SVHC: Unknown; Resistor Type: Thick Film; RoHS: Yes; RoHS Compliant: Yes; Series: CRCW; Size Code: 0805; Stock 1: 11753; Stock 2: 11753; Stock 3: 10000; Supplier 1: Digi-Key; Supplier 2: Digi-Key; Supplier 3: Digi-Key; Supplier Part Number 1: 541-1.60KCCT-ND; Supplier Part Number 2: 541-1.60KCDKR-ND; Supplier Part Number 3: 541-1.60KCTR-ND; Technology: THICK FILM; Termination: SMD/SMT; Tolerance: 1%; Voltage Rating (DC): 150V; Weight: 0.000194oz; Width: 0.049inch
R16 R17 R34 = CMP-2001-04908-2: RES Thick Film, 10kΩ, 1%, 0.125W, 100ppm/°C, 0805; Manufacturer 1: Vishay; Voltage Rating: 150V; Case Code (Imperial): 0805; Case Code (Metric): 2012; Case/Package: 0805; Category: Chip SMD Resistors; Component Kind: Standard; ComponentLink1Description: https://datasheet.ciiva.com/11808/0900766b80d98b26-11808216.pdf?src-supplier=RSComponents; ComponentLink1URL: https://datasheet.ciiva.com/11808/0900766b80d98b26-11808216.pdf?src-supplier=RSComponents; ComponentLink2Description: https://datasheet.ciiva.com/8337/dcrcwe3-8337773.pdf?src-supplier=Avnet; ComponentLink2URL: https://datasheet.ciiva.com/8337/dcrcwe3-8337773.pdf?src-supplier=Avnet; ComponentLink3Description: https://datasheet.ciiva.com/8337/dcrcwe3-8337774.pdf?src-supplier=Avnet; ComponentLink3URL: https://datasheet.ciiva.com/8337/dcrcwe3-8337774.pdf?src-supplier=Avnet; ComponentLink4Description: https://datasheet.ciiva.com/5547/dcrcwe3-109170-5547559.pdf?src-supplier=Mouser; ComponentLink4URL: https://datasheet.ciiva.com/5547/dcrcwe3-109170-5547559.pdf?src-supplier=Mouser; ComponentLink5Description: https://datasheet.ciiva.com/46394/1720486-46394381.pdf?src-supplier=Element14; ComponentLink5URL: https://datasheet.ciiva.com/46394/1720486-46394381.pdf?src-supplier=Element14; ComponentLink6Description: https://datasheet.ciiva.com/16199/dcrcwe3-16199086.pdf?src-supplier=Future+Electronics; ComponentLink6URL: https://datasheet.ciiva.com/16199/dcrcwe3-16199086.pdf?src-supplier=Future+Electronics; ComponentLink7Description: https://datasheet.ciiva.com/16883/dcrcwe3-16883708.pdf?src-supplier=Avnet+Europe; ComponentLink7URL: https://datasheet.ciiva.com/16883/dcrcwe3-16883708.pdf?src-supplier=Avnet+Europe; ComponentLink8Description: https://datasheet.ciiva.com/16719/70201119-16719082.pdf?src-supplier=Allied+Electronics; ComponentLink8URL: https://datasheet.ciiva.com/16719/70201119-16719082.pdf?src-supplier=Allied+Electronics; ComponentLink9Description: https://datasheet.ciiva.com/16514/dcrcwe3-16514907.pdf?src-supplier=Avnet+Asia; ComponentLink9URL: https://datasheet.ciiva.com/16514/dcrcwe3-16514907.pdf?src-supplier=Avnet+Asia; ComponentLink10Description: https://datasheet.ciiva.com/16755/70201119-16755389.pdf?src-supplier=Allied+Electronics; ComponentLink10URL: https://datasheet.ciiva.com/16755/70201119-16755389.pdf?src-supplier=Allied+Electronics; Composition: Thick Film; Construction: Rectangular; Contact Plating: Tin; Depth: 1.25mm; Features: Automotive AEC-Q200; Height: 0.45mm; Lead Free: Lead Free; Length: 2mm; Library Name: Altium Content Vault; Manufacturer 2: Vishay; Manufacturer 3: Vishay; Manufacturer 4: Vishay; Manufacturer Part Number 1: CRCW080510K0FKEA; Manufacturer Part Number 2: CRCW080510K0FKEA; Manufacturer Part Number 3: CRCW080510K0FKEA; Manufacturer Part Number 4: CRCW080510K0FKEA; Max Operating Temperature: 155°C; Military Standard: Not; Min Operating Temperature: -55°C; Number of Terminations: 2; Package Quantity: 5000; Packaging: Tape and Reel; Power Rating: 125mW; Pricing 1: 1=0.18000, 25=0.06500, 50=0.04600, 100=0.02700 (USD); Pricing 2: 1=0.10000, 10=0.06700, 100=0.02710, 1000=0.01219, 2500=0.01058 (USD); Pricing 3: 1=0.10000, 10=0.06700, 100=0.02710, 1000=0.01219, 2500=0.01058 (USD); Pricing 4: 5000=0.00874 (USD); Radiation Hardening: No; REACH SVHC: Unknown; Resistor Type: Thick Film; RoHS: Yes; RoHS Compliant: Yes; Series: CRCW; Size Code: 0805; Stock 1: 16518; Supplier 1: Newark; Supplier 2: Digi-Key; Supplier 3: Digi-Key; Supplier 4: Digi-Key; Supplier Part Number 1: 68AH8357; Supplier Part Number 2: 541-10.0KCCT-ND; Supplier Part Number 3: 541-10.0KCDKR-ND; Supplier Part Number 4: 541-10.0KCTR-ND; Technology: THICK FILM; Temperature Coefficient: 100ppm/°C; Termination: SMD/SMT; Tolerance: 1%; Voltage Rating (DC): 150V; Weight: 0.000194oz; Width: 1.25mm
R33 R35 = ERJP06J161V: RES SMD 160 OHM 5% 1/2W 0805; Manufacturer 1: Panasonic; Manufacturer Part Number 1: ERJ-P06J161V; Max Power Dissipation: 500mW; Temperature Coefficient: 200ppm/°C; Voltage Rating: 150V; Availability: Unavailable; Case Code (Imperial): 0805; Case Code (Metric): 2012; Case/Package: 0805; Category: Chip SMD Resistors; Component Kind: Standard; ComponentLink1Description: http://www.mouser.com/ds/2/315/AOA0000CE4-64075.pdf?src-supplier=Mouser; ComponentLink1URL: http://www.mouser.com/ds/2/315/AOA0000CE4-64075.pdf?src-supplier=Mouser; ComponentLink2Description: http://www.onlinecomponents.com/datasheet/erj-p06j161v.aspx?p=44459141&src-supplier=Onlinecomponents.com; ComponentLink2URL: http://www.onlinecomponents.com/datasheet/erj-p06j161v.aspx?p=44459141&src-supplier=Onlinecomponents.com; ComponentLink3Description: https://industrial.panasonic.com/cdbs/www-data/pdf/RDO0000/AOA0000C331.pdf?src-supplier=Digi-Key; ComponentLink3URL: https://industrial.panasonic.com/cdbs/www-data/pdf/RDO0000/AOA0000C331.pdf?src-supplier=Digi-Key; ComponentLink4Description: https://industrial.panasonic.com/ww/system/files/data/download/catalog/id_fixed-resistors_e_1.pdf?src-supplier=Digi-Key; ComponentLink4URL: https://industrial.panasonic.com/ww/system/files/data/download/catalog/id_fixed-resistors_e_1.pdf?src-supplier=Digi-Key; ComponentLink5Description: http://industrial.panasonic.com/www-data/pdf/AOA0000/AOA0000CE4.pdf?src-supplier=Avnet; ComponentLink5URL: http://industrial.panasonic.com/www-data/pdf/AOA0000/AOA0000CE4.pdf?src-supplier=Avnet; ComponentLink6Description: http://industrial.panasonic.com/www-data/pdf/AOA0000/AOA0000CE4.pdf?src-supplier=Avnet+Europe; ComponentLink6URL: http://industrial.panasonic.com/www-data/pdf/AOA0000/AOA0000CE4.pdf?src-supplier=Avnet+Europe; Contact Plating: Tin; Features: Automotive AEC-Q200; Height: 0.028inch; Lead Free: Contains Lead; Length: 0.079inch; Max Operating Temperature: 155°C; Min Operating Temperature: -55°C; Mount: Surface Mount; MP: ERJP06J161V; Number of Terminations: 2; Package: None; Package Quantity: 5000; Packaging: Tape and Reel; Power Rating: 500mW; Price: None; Pricing 1: 1=0.12000, 10=0.10800, 100=0.04140, 1000=0.01822, 2500=0.01573 (USD); Radiation Hardening: No; Resistor Type: Thick Film; RoHS: Yes; RoHS Compliant: Yes; Series: ERJ; Stock 1: 25408; Supplier 1: Digi-Key; Supplier Part Number 1: P160ADCT-ND; Tolerance: 5%; Voltage Rating (DC): 150V; Width: 1.2mm; Library Name: BMS_MasterboardUpdated_1.SCHLIB
U3 = CMP-2000-07488-1: Swicth, SPST, 24 V, -35 to 85 degC, 4-Pin SMD, RoHS, Tape and Reel; Manufacturer 1: E-Switch; Actuator Length: 0.7mm; Actuator Material: Nylon,Polyamide; Actuator Type: Standard; Contact Current Rating: 50mA; Contact Resistance: 100mR; Electrical Life: 100000Cycles; Illumination Type: Non-Illuminated,Illuminated; Max Voltage Rating (DC): 50V; Operating Force: 260gf; Switch Function: Off-Mom; Switch Travel: 0.25mm; Voltage Rating (DC): 12V; Category: Tactile Switches; Color: Black; Component Kind: Standard; ComponentLink1Description: https://datasheet.ciiva.com/2901/p010517e-2901296.pdf?src-supplier=Digi-Key; ComponentLink1URL: https://datasheet.ciiva.com/2901/p010517e-2901296.pdf?src-supplier=Digi-Key; ComponentLink2Description: https://datasheet.ciiva.com/2901/tl3301-2901294.pdf?src-supplier=Digi-Key; ComponentLink2URL: https://datasheet.ciiva.com/2901/tl3301-2901294.pdf?src-supplier=Digi-Key; ComponentLink3Description: https://datasheet.ciiva.com/2901/tl3301-2901295.pdf?src-supplier=Digi-Key; ComponentLink3URL: https://datasheet.ciiva.com/2901/tl3301-2901295.pdf?src-supplier=Digi-Key; ComponentLink4Description: https://datasheet.ciiva.com/7637/tl3301-7637661.pdf?src-supplier=Element14; ComponentLink4URL: https://datasheet.ciiva.com/7637/tl3301-7637661.pdf?src-supplier=Element14; Contact Material: Silver; Contact Plating: Silver; Current Rating: 50mA; Insulation Resistance: 100MR; Lead Free: Lead Free; Length: 6mm; Library Name: Altium Content Vault; Manufacturer Part Number 1: TL3301NF260QG; Max Operating Temperature: 70°C; Mechanical Life: 100000Cycles; Min Operating Temperature: -20°C; Mount: Surface Mount; Orientation: Straight; Package Quantity: 1; Packaging: Tape and Reel; Pricing 1: 1=0.42000, 10=0.40400, 25=0.38040, 100=0.33030, 250=0.29968, 500=0.27876 (USD); REACH SVHC: No SVHC; RoHS: Yes; RoHS Compliant: Yes; Series: TL3301; Stock 1: 8223; Supplier 1: Digi-Key; Supplier 2: Digi-Key; Supplier Part Number 1: EG1868CT-ND; Supplier Part Number 2: EG1868CT-ND; Termination: Gull Wing; Throw Configuration: SPST; Voltage Rating: 50V; Width: 6mm
